# Altium schematic sheet 08_Power.SchDoc(08_Power)
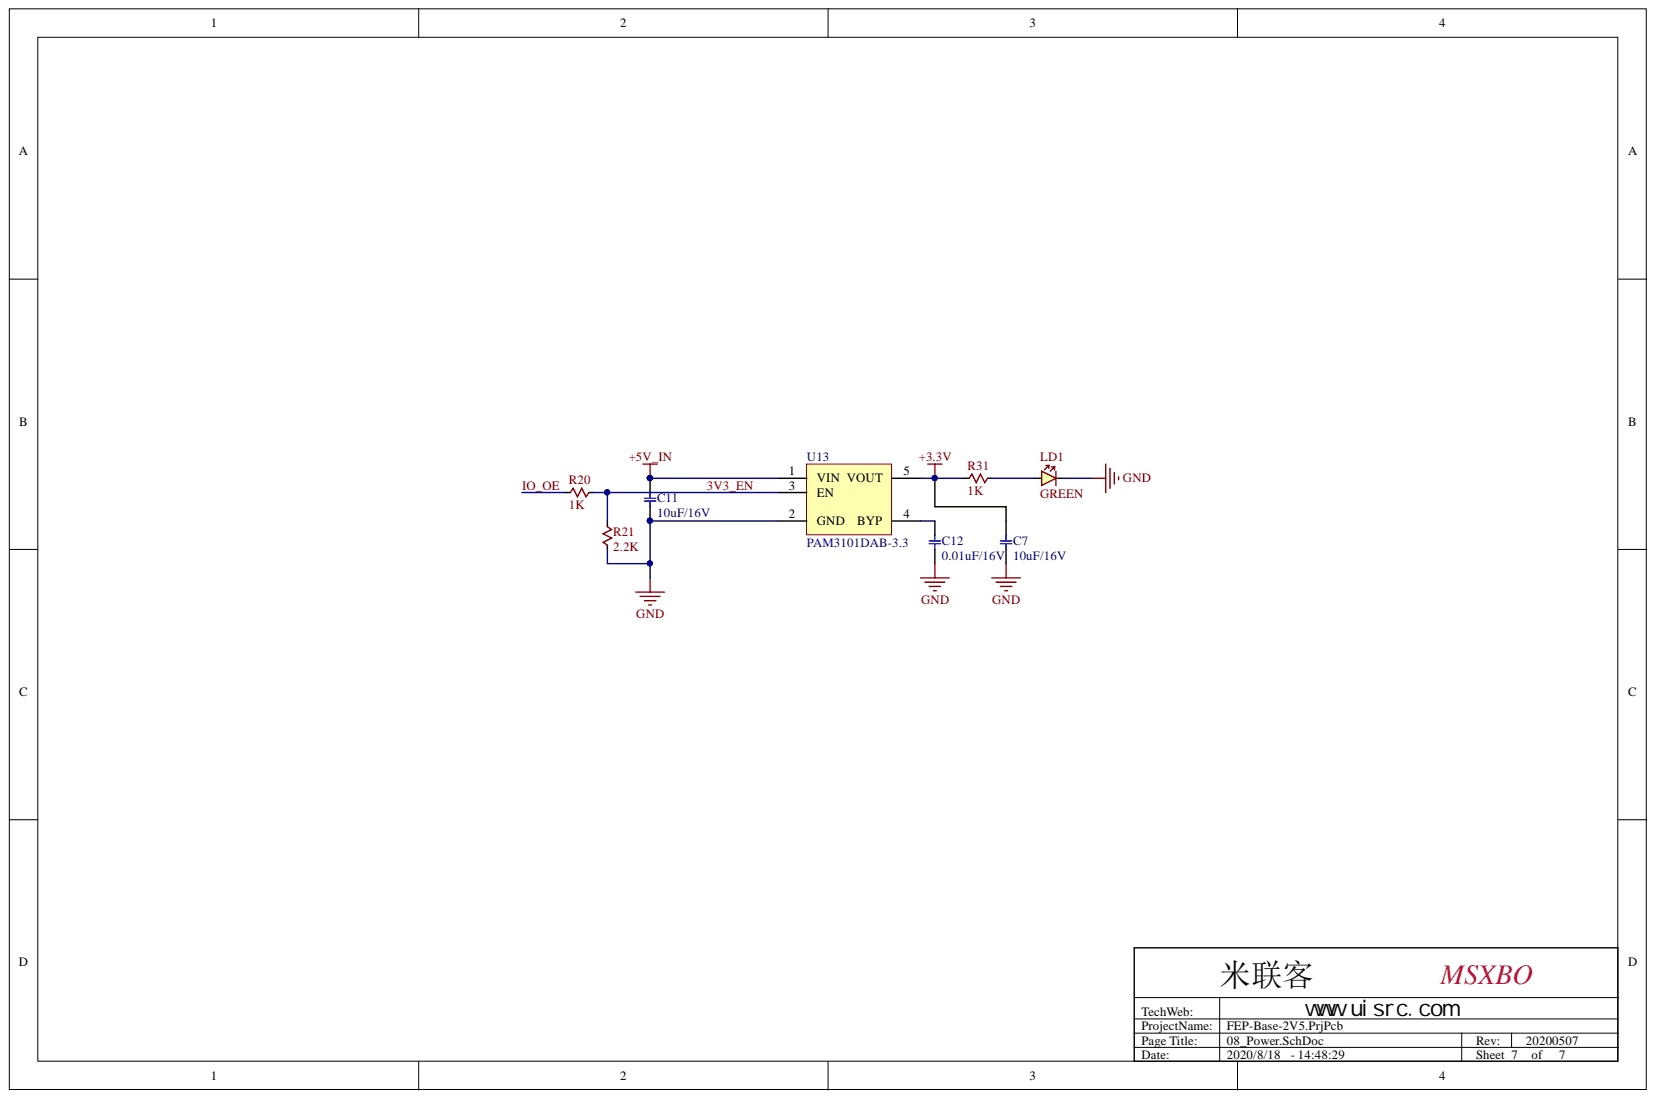
[diagram p0: 08_Power.SchDoc]

## Parts
C7  10uF/16V  [cap0805]
C11  10uF/16V  [cap0805]
C12  0.01uF/16V  [cap0603]
LD1  value GREEN  [D0603]  (hidden comment: LED)
R20  1K  [RES0402]
R21  2.2K  [RES0402]
R31  1K  [RES0402]
U13  PAM3101DAB-3.3  [SOT-23-5]

## Nets
+3.3V: C7.2 R31.2 U13.5(VOUT)
+5V_IN: C11.2 U13.1(VIN)
GND: C7.1 C11.1 C12.1 LD1.K R21.1 U13.2(GND)
3V3_EN: R20.1 R21.2 U13.3(EN)
IO_OE: R20.2
NetC12_2: C12.2 U13.4(BYP)
NetLD1_A: LD1.A R31.1

## Library data of the parts
C7 C11 = CAP_10uF/16V: Capacitor; Component Kind: Standard; Library Name: EnHom Frequently Used.SchLib
C12 = CAP_0.1uF/16V: Capacitor; Component Kind: Standard; Library Name: EnHom Frequently Used.SchLib
LD1 = LED: Generic LED; Component Kind: Standard; Library Name: Digilent.IntLib
R20 R21 R31 = RESISTOR: Generic Resistor; Value: 390; Component Kind: Standard; Library Name: Miz702fun_20160501.IntLib
U13 = IC_PWR_PAM3101DAB-3.3: 300mA High PSRR Low Dropout CMOS Linear Regulator; Component Kind: Standard; Library Name: EnHom Frequently Used.SchLib
